# Altium schematic sheet MOTORES PPM.SchDoc
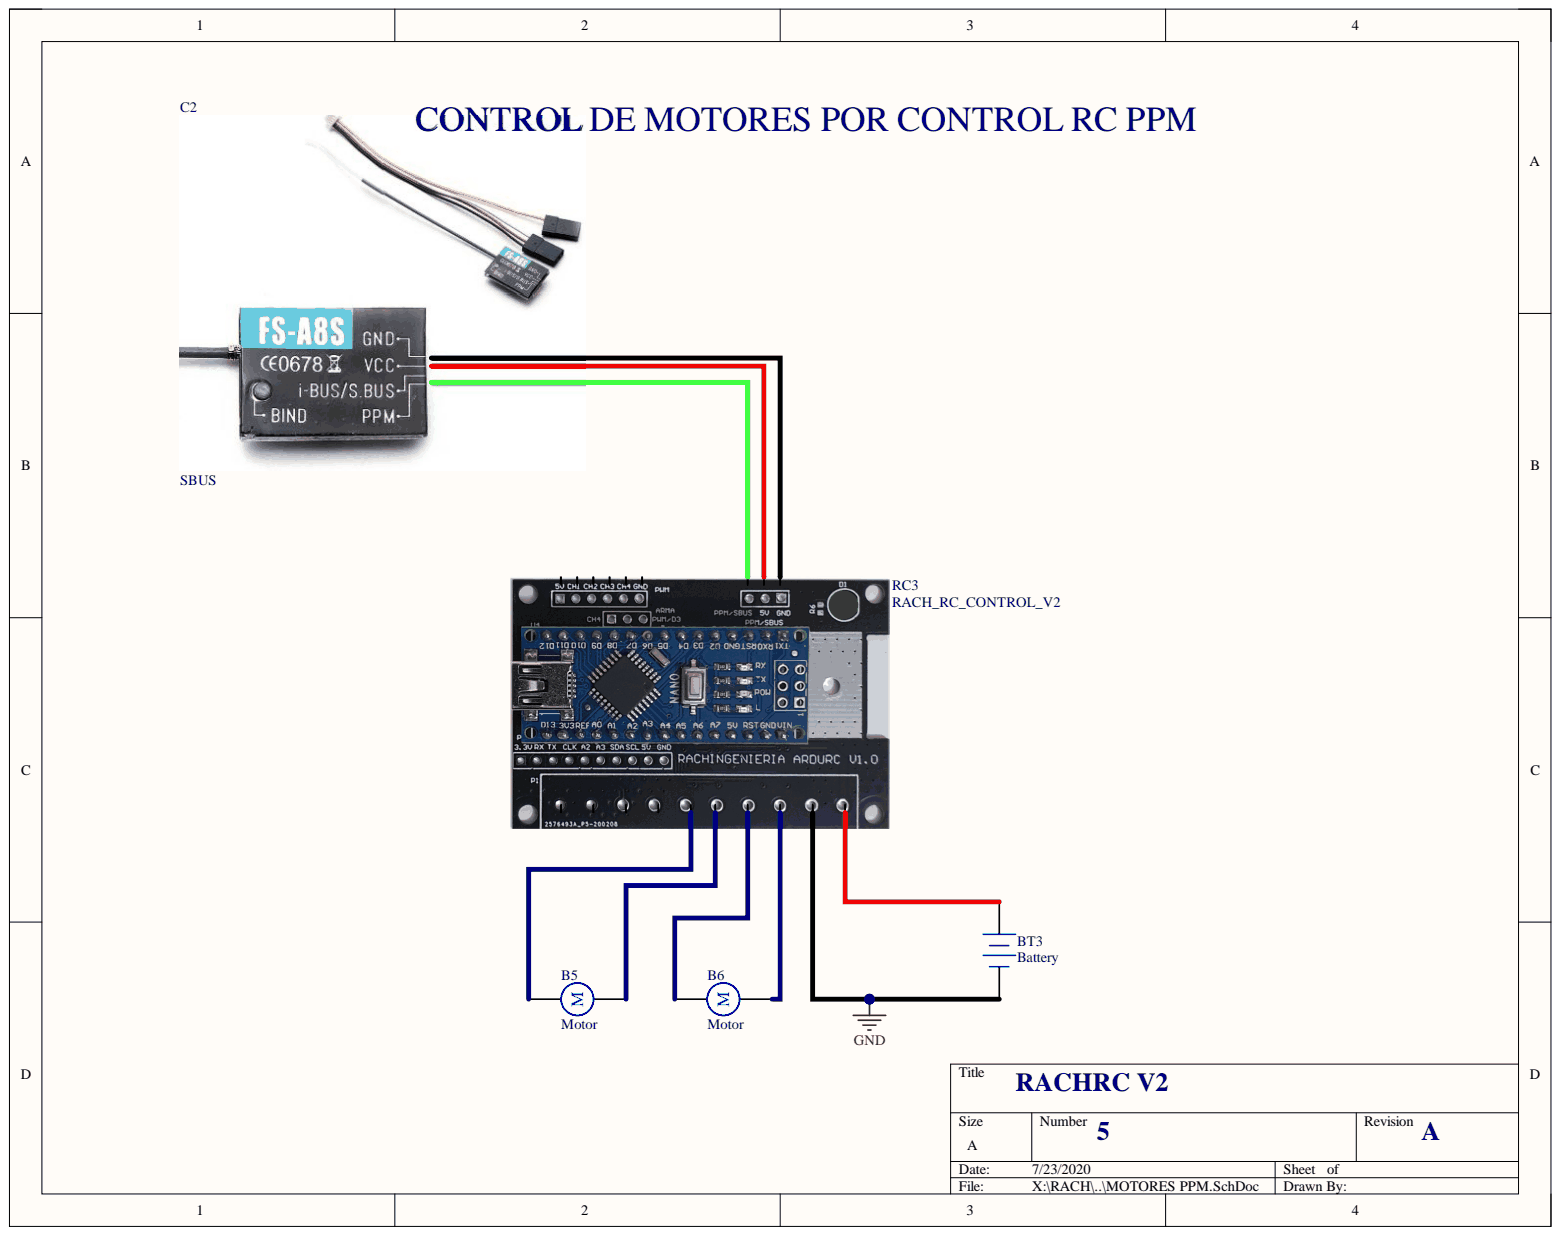
[diagram p0: MOTORES PPM.SchDoc]

## Parts
B5  Motor  [RB5-10.5]
B6  Motor  [RB5-10.5]
BT3  Battery  [BAT-2]
C2  SBUS
RC3  RACH_RC_CONTROL_V2

## Nets
GND: BT3.2 RC3.19
NetB5_1: B5.1 RC3.15
NetB5_2: B5.2 RC3.16
NetB6_1: B6.1 RC3.17
NetB6_2: B6.2 RC3.18
NetBT3_1: BT3.1 RC3.20
NetC2_1: C2.1 RC3.10
NetC2_2: C2.2 RC3.9
NetC2_4: C2.4 RC3.8

Not connected: C2 3; RC3 2 3 4 5 6 7 11 12 13 14

## Library data of the parts
B5 B6 = Motor: Motor, General Kind; Component Kind: Standard; LatestRevisionDate: 17-Jul-2002; LatestRevisionNote: Re-released for DXP Platform.; Library Name: Miscellaneous Devices.IntLib; PackageDescription: Capacitor (Radial); 2 Leads; PackageReference: RB5-10.5; Published: 24-Mar-1999; Publisher: Altium Limited
BT3 = Battery: Multicell Battery; Component Kind: Standard; LatestRevisionDate: 17-Jul-2002; LatestRevisionNote: Re-released for DXP Platform.; Library Name: Miscellaneous Devices.IntLib; PackageDescription: Battery; 2 Leads; PackageReference: BAT-2; Published: 24-Mar-1999; Publisher: Altium Limited
C2 = SBUS: Component Kind: Standard; Library Name: Componentes.SchLib
RC3 = RACH_RC_CONTROL_V2: Component Kind: Standard; Library Name: Componentes.SchLib
